# Altium schematic sheet Teensy and ICs.SchDoc
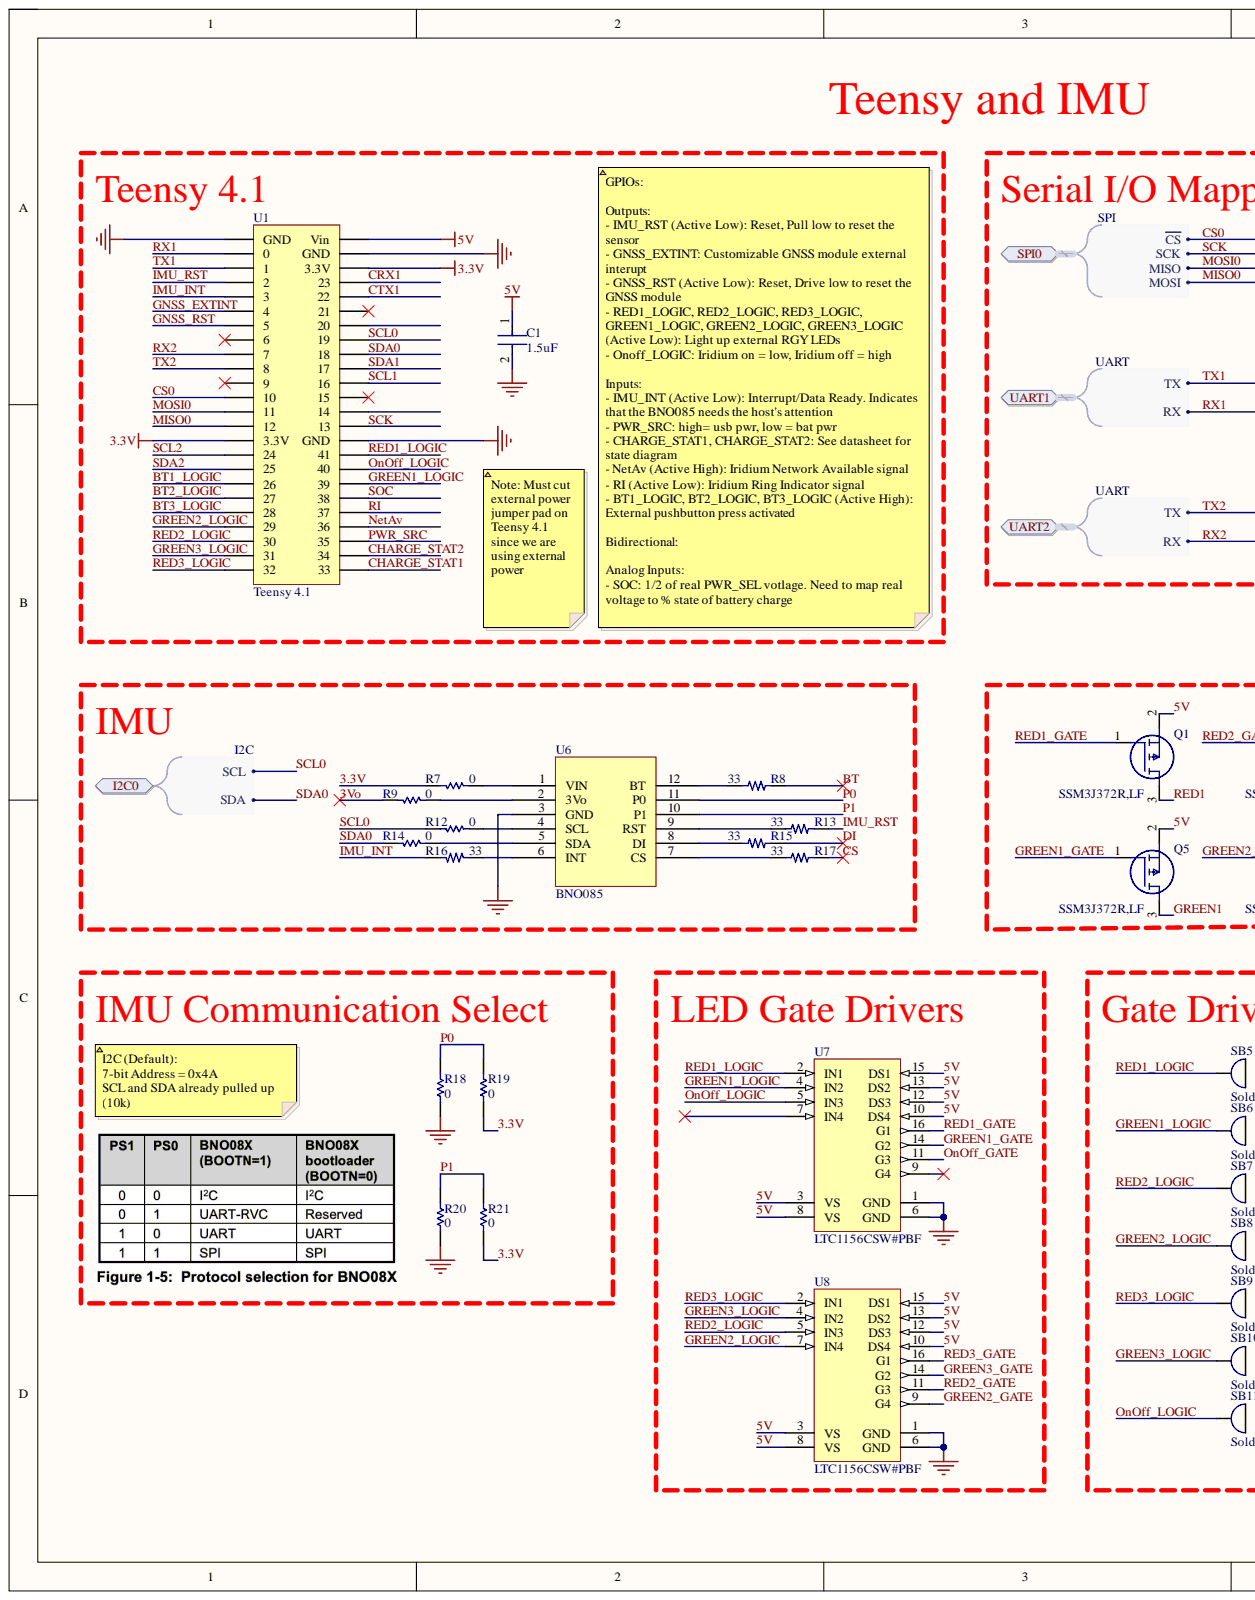
[diagram p0: Teensy and ICs.SchDoc, left]
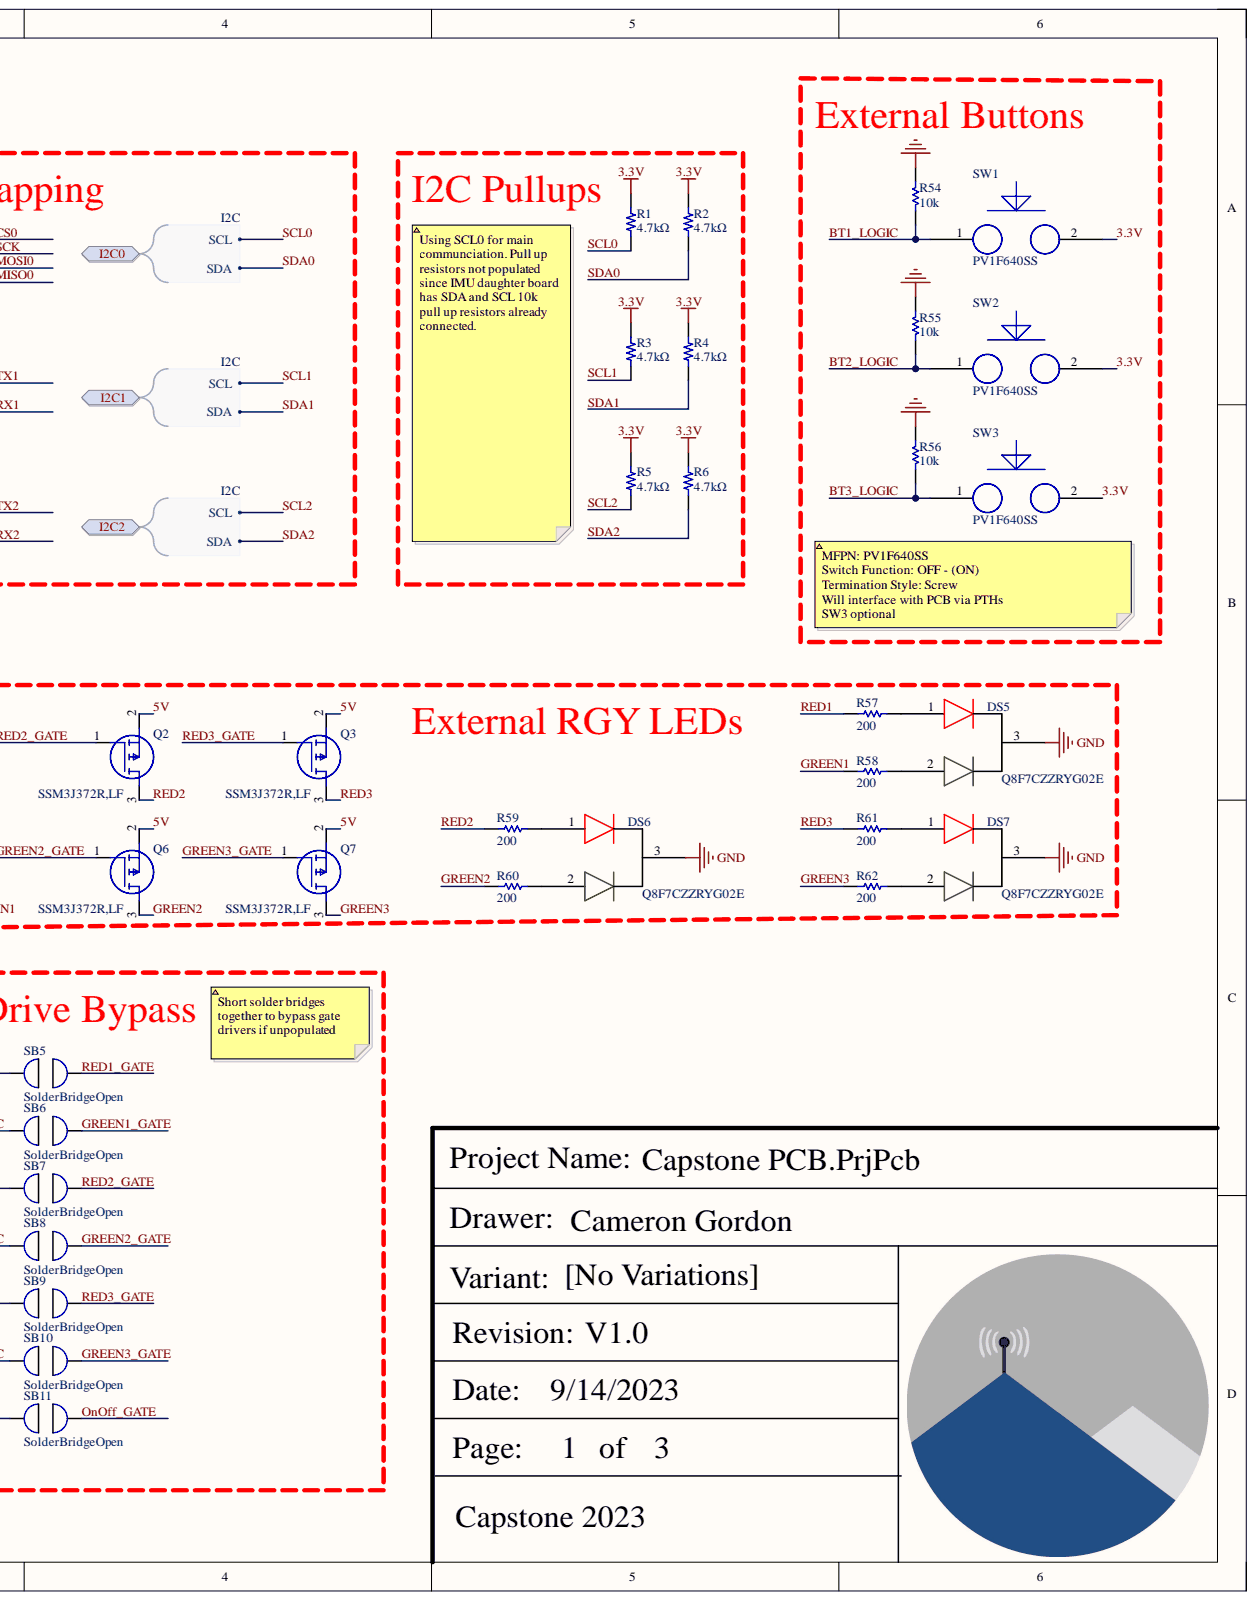
[diagram p1: Teensy and ICs.SchDoc, right]

## Parts
C1  Capacitance: 1.5uF  [FP-C2012-125-0_2-MFG]  (hidden comment: C2012X7R1E155K125AC)
DS5  Q8F7CZZRYG02E  [Q8F7CZZRYG02E]
DS6  Q8F7CZZRYG02E  [Q8F7CZZRYG02E]
DS7  Q8F7CZZRYG02E  [Q8F7CZZRYG02E]
Q1  SSM3J372R,LF  [SSM3J372RLF]
Q2  SSM3J372R,LF  [SSM3J372RLF]
Q3  SSM3J372R,LF  [SSM3J372RLF]
Q5  SSM3J372R,LF  [SSM3J372RLF]
Q6  SSM3J372R,LF  [SSM3J372RLF]
Q7  SSM3J372R,LF  [SSM3J372RLF]
R1  Resistance: 4.7kΩ  [0603 Resistor]  (hidden comment: ERJ-3GEYJ472V)
R2  Resistance: 4.7kΩ  [0603 Resistor]  (hidden comment: ERJ-3GEYJ472V)
R3  Resistance: 4.7kΩ  [0603 Resistor]  (hidden comment: ERJ-3GEYJ472V)
R4  Resistance: 4.7kΩ  [0603 Resistor]  (hidden comment: ERJ-3GEYJ472V)
R5  Resistance: 4.7kΩ  [0603 Resistor]  (hidden comment: ERJ-3GEYJ472V)
R6  Resistance: 4.7kΩ  [0603 Resistor]  (hidden comment: ERJ-3GEYJ472V)
R7  RC0603JR-070RL  [RESC1608X55X25ML10T15]  (value not drawn)
R8  CRGCQ0603J33R  [0603 Resistor]  (value not drawn)
R9  RC0603JR-070RL  [RESC1608X55X25ML10T15]  (value not drawn)
R12  RC0603JR-070RL  [RESC1608X55X25ML10T15]  (value not drawn)
R13  CRGCQ0603J33R  [0603 Resistor]  (value not drawn)
R14  RC0603JR-070RL  [RESC1608X55X25ML10T15]  (value not drawn)
R15  CRGCQ0603J33R  [0603 Resistor]  (value not drawn)
R16  CRGCQ0603J33R  [0603 Resistor]  (value not drawn)
R17  CRGCQ0603J33R  [0603 Resistor]  (value not drawn)
R18  RC0603JR-070RL  [RESC1608X55X25ML10T15]  (value not drawn)
R19  RC0603JR-070RL  [RESC1608X55X25ML10T15]  (value not drawn)
R20  RC0603JR-070RL  [RESC1608X55X25ML10T15]  (value not drawn)
R21  RC0603JR-070RL  [RESC1608X55X25ML10T15]  (value not drawn)
R54  Resistance: 10k  [RESC1609X55X30LL15T15]  (hidden comment: ERJ3EKF1002V)
R55  Resistance: 10k  [RESC1609X55X30LL15T15]  (hidden comment: ERJ3EKF1002V)
R56  Resistance: 10k  [RESC1609X55X30LL15T15]  (hidden comment: ERJ3EKF1002V)
R57  value 200  [RESC2013X60X35ML10T20]  (hidden comment: RC0805JR-07200RL)
R58  value 200  [RESC2013X60X35ML10T20]  (hidden comment: RC0805JR-07200RL)
R59  value 200  [RESC2013X60X35ML10T20]  (hidden comment: RC0805JR-07200RL)
R60  value 200  [RESC2013X60X35ML10T20]  (hidden comment: RC0805JR-07200RL)
R61  value 200  [RESC2013X60X35ML10T20]  (hidden comment: RC0805JR-07200RL)
R62  value 200  [RESC2013X60X35ML10T20]  (hidden comment: RC0805JR-07200RL)
SB5  SolderBridgeOpen  [SOLDERBRIDGEOPEN]
SB6  SolderBridgeOpen  [SOLDERBRIDGEOPEN]
SB7  SolderBridgeOpen  [SOLDERBRIDGEOPEN]
SB8  SolderBridgeOpen  [SOLDERBRIDGEOPEN]
SB9  SolderBridgeOpen  [SOLDERBRIDGEOPEN]
SB10  SolderBridgeOpen  [SOLDERBRIDGEOPEN]
SB11  SolderBridgeOpen  [SOLDERBRIDGEOPEN]
SW1  PV1F640SS  [PV1F640SS-Footprint-1]
SW2  PV1F640SS  [PV1F640SS-Footprint-1]
SW3  PV1F640SS  [PV1F640SS-Footprint-1]
U1  Teensy 4.1  [Teensy_4.1]
U6  BNO085  [BNO085]
U7  LTC1156CSW#PBF  [LT-SW-16_L]
U8  LTC1156CSW#PBF  [LT-SW-16_L]

## Ports
I2C0, I2C0.SCL, I2C0.SDA, I2C1, I2C1.SCL, I2C1.SDA, I2C2, I2C2.SCL, I2C2.SDA, SPI0, SPI0.MISO, SPI0.MOSI, SPI0.SCK, SPI0~{.CS}, UART1, UART1.RX, UART1.TX, UART2, UART2.RX, UART2.TX

## Nets
GND: C1.2 DS5.3 DS6.3 DS7.3 R18.1 R20.1 R54.1 R55.1 R56.1 U1.1(GND) U1.34(GND) U1.47(GND) U6.3(GND) U7.1(GND) U7.6(GND) U8.1(GND) U8.6(GND)
3.3V: R1.2 R2.2 R3.2 R4.2 R5.2 R6.2 R7.1 R19.1 R21.1 SW1.2 SW2.2 SW3.2 U1.15(3.3V) U1.46(3.3V)
3Vo: R9.1
5V: C1.1 Q1.2 Q2.2 Q3.2 Q5.2 Q6.2 Q7.2 U1.48(Vin) U7.3(VS) U7.8(VS) U7.10(DS4) U7.12(DS3) U7.13(DS2) U7.15(DS1) U8.3(VS) U8.8(VS) U8.10(DS4) U8.12(DS3) U8.13(DS2) U8.15(DS1)
BT: R8.2
BT1_LOGIC: R54.2 SW1.1 U1.18
BT2_LOGIC: R55.2 SW2.1 U1.19
BT3_LOGIC: R56.2 SW3.1 U1.20
CHARGE_STAT1: U1.25
CHARGE_STAT2: U1.26
CRX1: U1.45
CS: R17.2
CS0: U1.12 ; port SPI0
CTX1: U1.44
DI: R15.2
GNSS_EXTINT: U1.6
GNSS_RST: U1.7
GREEN1: Q5.3 R58.2
GREEN1_GATE: Q5.1 SB6.1 U7.14(G2)
GREEN1_LOGIC: SB6.2 U1.31 U7.4(IN2)
GREEN2: Q6.3 R60.2
GREEN2_GATE: Q6.1 SB8.1 U8.9(G4)
GREEN2_LOGIC: SB8.2 U1.21 U8.7(IN4)
GREEN3: Q7.3 R62.2
GREEN3_GATE: Q7.1 SB10.1 U8.14(G2)
GREEN3_LOGIC: SB10.2 U1.23 U8.4(IN2)
IMU_INT: R16.2 U1.5
IMU_RST: R13.2 U1.4
MISO0: U1.14 ; port SPI0
MOSI0: U1.13 ; port SPI0
NetAv: U1.28
OnOff_GATE: SB11.1 U7.11(G3)
OnOff_LOGIC: SB11.2 U1.32 U7.5(IN3)
P0: R18.2 R19.2 U6.11(P0)
P1: R20.2 R21.2 U6.10(P1)
PWR_SRC: U1.27
RED1: Q1.3 R57.2
RED1_GATE: Q1.1 SB5.1 U7.16(G1)
RED1_LOGIC: SB5.2 U1.33 U7.2(IN1)
RED2: Q2.3 R59.2
RED2_GATE: Q2.1 SB7.1 U8.11(G3)
RED2_LOGIC: SB7.2 U1.22 U8.5(IN3)
RED3: Q3.3 R61.2
RED3_GATE: Q3.1 SB9.1 U8.16(G1)
RED3_LOGIC: SB9.2 U1.24 U8.2(IN1)
RI: U1.29
RX1: U1.2 ; port UART1
RX2: U1.9 ; port UART2
SCK: U1.35 ; port SPI0
SCL0: R1.1 R12.1 U1.41 ; port I2C0
SCL1: R3.1 U1.38 ; port I2C1
SCL2: R5.1 U1.16 ; port I2C2
SDA0: R2.1 R14.1 U1.40 ; port I2C0
SDA1: R4.1 U1.39 ; port I2C1
SDA2: R6.1 U1.17 ; port I2C2
SOC: U1.30
TX1: U1.3 ; port UART1
TX2: U1.10 ; port UART2
NetDS5_1: DS5.1 R57.1
NetDS5_2: DS5.2 R58.1
NetDS6_1: DS6.1 R59.1
NetDS6_2: DS6.2 R60.1
NetDS7_1: DS7.1 R61.1
NetDS7_2: DS7.2 R62.1
NetR7_2: R7.2 U6.1(VIN)
NetR8_1: R8.1 U6.12(BT)
NetR9_2: R9.2 U6.2(3Vo)
NetR12_2: R12.2 U6.4(SCL)
NetR13_1: R13.1 U6.9(RST)
NetR14_2: R14.2 U6.5(SDA)
NetR15_1: R15.1 U6.8(DI)
NetR16_1: R16.1 U6.6(INT)
NetR17_1: R17.1 U6.7(CS)

Not connected: U1 8 11 36 37 42 43; U7 7(IN4) 9(G4)

## Library data of the parts
C1 = CMP-08246-004820-1: Multilayer Ceramic Capacitors 1.5μF ±10% 25V X7R SMD 0805; Case Code (Imperial): 0805; Case Code (Metric): 2012; Case/Package: 0805; Component Kind: Standard; Dielectric: X7R; Dielectric Material: Ceramic; Height: 1.25mm; Lead Free: Lead Free; Length: 0.079inch; Library Name: Altium Content Vault; Max Operating Temperature: 125°C; Min Operating Temperature: -55°C; Mount: Surface Mount; Package Quantity: 1; Packaging: Tape and Reel; Resistor Type: Ceramic; RoHS Compliant: Yes; Termination: SMD/SMT; Thickness: 0.057inch; Tolerance: 10%; Voltage Rating: 25V; Voltage Rating (DC): 25V; Weight: 0.000194oz; Width: 0.049inch
Q1-Q3 Q5-Q7 = SSM3J372R,LF: MOSFET (P-Channel); Manufacturer_Name: Toshiba; Arrow Part Number: SSM3J372R,LF; Arrow Price/Stock: https://www.arrow.com/en/products/ssm3j372rlf/toshiba?region=nac; Component Kind: Standard; Datasheet Link: https://toshiba.semicon-storage.com/ap-en/semiconductor/product/mosfets/detail.SSM3J372R.html; Height: 0.88mm; Manufacturer_Part_Number: SSM3J372R,LF; Mouser Part Number: 757-SSM3J372RLF; Mouser Price/Stock: https://www.mouser.co.uk/ProductDetail/Toshiba/SSM3J372RLF?qs=u16ybLDytRbJaSMlwq9MLA%3D%3D
R1-R6 = CMP-001-0036-2: 0603 Resistor, 4.7kΩ, ±5%, 0.1W; Power Rating: 100mW; Component Kind: Standard; Package: 0603; Tolerance: 5%; Library Name: Northeastern Electric Racing
R7 R9 R12 R14 R18-R21 = CMP-2100-03667-1: Chip Resistor, 0 Ohm, +/- 5%, 0.1 W, -55 to 155 degC, 0603 (1608 Metric), RoHS, Tape and Reel; Manufacturer: Yageo; Power Rating: 100mW; Resistance: 0; Temperature Coefficient: 200ppm/degreesC; Voltage Rating: 50V; Case Code (Imperial): 0603; Case Code (Metric): 1608; Case/Package: 0603; Component Kind: Standard; Composition: ThickFilm; Contact Plating: Tin; Datasheet URL: http://www.yageo.com/NewPortal/yageodocoutput?fileName=/pdf/R-Chip/PYu-RC_Group_51_RoHS_L_5.pdf; Datasheet Version: Rev. 5, 10/2016; Depth: 0.8mm; Height: 0.45mm; Lead Free: LeadFree; Length: 1.6mm; Library Name: Altium Content Vault; Manufacturer URL: http://www.yageo.com/; Max Operating Temperature: 155degC; Military Standard: Not; Min Operating Temperature: -55degC; Number of Pins: 2; Package Description: 2-Pin Surface Mount Device, Body 1.6 x 0.8 mm; Package Quantity: 5000; Package Reference: 0603; Packaging: TapeandReel; Radiation Hardening: No; REACH SVHC: NoSVHC; RoHSCompliant: true; Termination: SMD/SMT; Tolerance: 5%; Voltage Rating (DC): 50V; Width: 0.8mm
R8 R13 R15-R17 = CMP-00148-2: Res Thick Film 0603 33 Ohm 5% 0.1W(1/10W) ±200ppm/C Pad SMD Automotive T/R; Power Rating: 100mW; Resistance: 33; Component Kind: Standard; Package: 0603; Tolerance: 5%; Library Name: Northeastern Electric Racing
R54-R56 = CMP-1012-00623-3: Chip Resistor, 10 KOhm, +/- 1%, 0.1 W, -55 to 155 degC, 0603 (1608 Metric), RoHS, Tape and Reel; Manufacturer: Panasonic; Case\/Package: 0603; Max Power Dissipation: 100mW; Temperature Coefficient: 100ppm\/degreesC; Voltage Rating: 75V; Case Code (Imperial): 0603; Case Code (Metric): 1608; Component Kind: Standard; Composition: ThickFilm; Contact Plating: Tin; Datasheet URL: https://industrial.panasonic.com/cdbs/www-data/pdf/RDA0000/AOA0000C304.pdf; Datasheet Version: 02/2017; Depth: 0.8mm; Height: 0.45mm; Lead Free: LeadFree; Length: 1.6mm; Library Name: Altium Content Vault; Manufacturer URL: http://www.panasonic.com/; Max Operating Temperature: 155degC; Military Standard: Not; Min Operating Temperature: -55degC; Mount: SurfaceMount; Mounting Technology: SurfaceMount; Number of Pins: 2; Number of Terminations: 2; Package Description: 2-Pin Surface Mount Device, Body 1.6 x 0.85 mm; Package Quantity: 1; Package Reference: 603; Packaging: TapeandReel; Power Rating: 100mW; Radiation Hardening: No; REACH SVHC: NoSVHC; RoHSCompliant: true; Termination: SMD/SMT; Tolerance: 1%; Voltage Rating (DC): 75V; Width: 0.031inch
R57-R62 = CMP-2001-01354-1: Manufacturer: Yageo; Component Kind: Standard; Library Name: Altium Content Vault; Part Number: RC0805JR-07200RL
SW1-SW3 = CMP-011-00013-1: Pushbutton Switch; Current Rating: 2A; Movement Type: Momentary, push button, slide, toggle, etc; Throw Configuration: DPST, SPST, etc; Voltage Rating: 48V; Component Kind: Standard; Library Name: Northeastern Electric Racing
U1 = CMP-00040-2: Teensy 4.1 w/ Headers; Component Kind: Standard; Library Name: Northeastern Electric Racing
U6 = BNO085: Adafruit 9-DOF Orientation IMU Fusion Breakout - BNO085; Component Kind: Standard; Library Name: BNO085.SchLib
U7 U8 = CMP-0479-00627-1: Quad High Side Micropower MOSFET Driver with Internal Charge Pump, 22 V Vin MAX, 16-pin SOIC (SW-16), 0 to 70 degC, Pb-Free; Manufacturer: Linear Technology; Component Kind: Standard; ComponentLink1Description: Manufacturer URL; ComponentLink1URL: http://www.linear.com/; ComponentLink2Description: Datasheet; ComponentLink2URL: http://cds.linear.com/docs/en/datasheet/lt1156.pdf; ComponentLink3Description: Package Specification; ComponentLink3URL: http://www.linear.com/docs/en/packaging/SO_16_05-08-1620.pdf; DatasheetVersion: Rev. A; Library Name: Altium Content Vault; Mounting Technology: Surface Mount; PackageDescription: 16-Pin Plastic Small Outline, Body 10.30 x 7.49 mm, Pitch 1.27 mm; PackageReference: SW-16; PackageVersion: Jun-10; PartNumber: LTC1156CSW#PBF; RoHS: TRUE
DS5-DS7 = Q8F7CZZRYG02E: Component Kind: Standard; Library Name: RGY LEDs.SchLib
SB5-SB11 = SolderBridgeOpen: Component Kind: Standard; Library Name: SolderBridge.SchLib
